# Altium schematic sheet Power.SchDoc
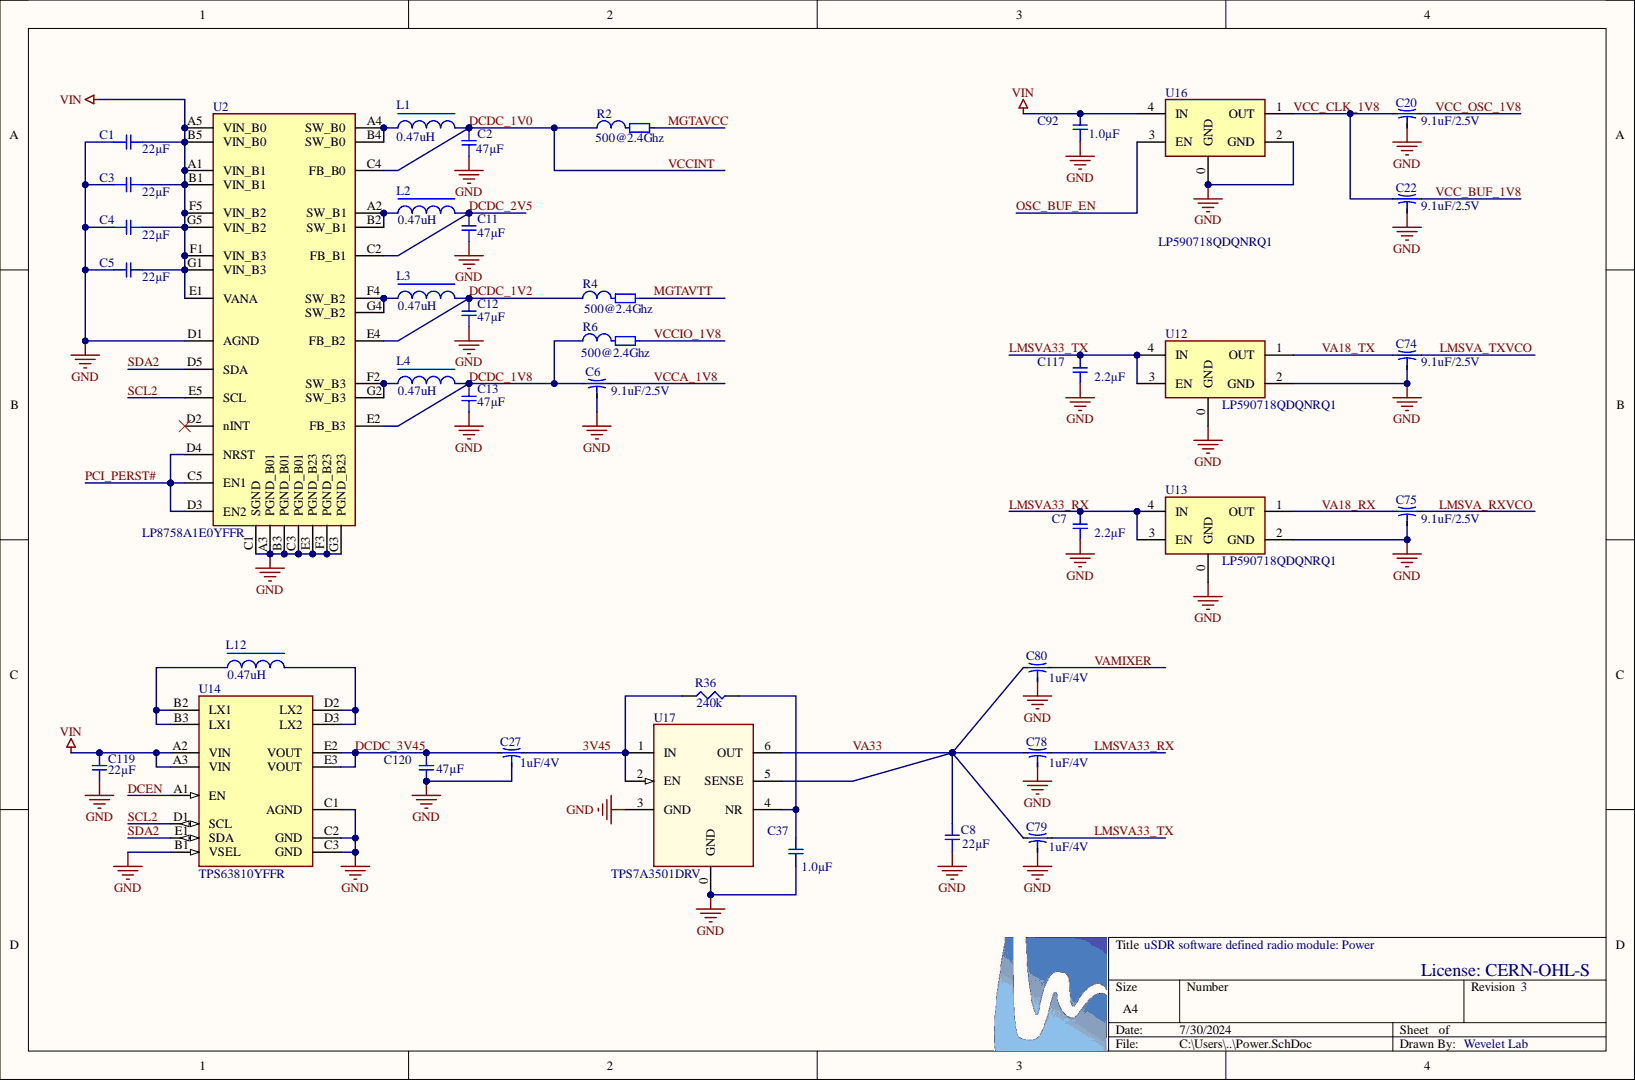
[diagram p0: Power.SchDoc]

## Parts
C1  value 22µF  [SMD-0603-CAP_HighDensity]  (hidden comment: GRM188C81A226ME01D)
C2  value 47µF  [SMD-0603-CAP_HighDensity]  (hidden comment: GRM188C80G476ME01D)
C3  value 22µF  [SMD-0603-CAP_HighDensity]  (hidden comment: GRM188C81A226ME01D)
C4  value 22µF  [SMD-0603-CAP_HighDensity]  (hidden comment: GRM188C81A226ME01D)
C5  value 22µF  [SMD-0603-CAP_HighDensity]  (hidden comment: GRM188C81A226ME01D)
C6  value 9.1uF/2.5V  [NFM15]  (hidden comment: NFM15PC915D0E3)
C7  value 2.2µF  [SMD-0201C]  (hidden comment: GRM033C80G225ME01D)
C8  value 22µF  [SMD-0603-CAP_HighDensity]  (hidden comment: GRM188C81A226ME01D)
C11  value 47µF  [SMD-0603-CAP_HighDensity]  (hidden comment: GRM188C80G476ME01D)
C12  value 47µF  [SMD-0603-CAP_HighDensity]  (hidden comment: GRM188C80G476ME01D)
C13  value 47µF  [SMD-0603-CAP_HighDensity]  (hidden comment: GRM188C80G476ME01D)
C20  value 9.1uF/2.5V  [NFM15]  (hidden comment: NFM15PC915D0E3)
C22  value 9.1uF/2.5V  [NFM15]  (hidden comment: NFM15PC915D0E3)
C27  value 1uF/4V  [NFM15]  (hidden comment: NFM15PC105D0G3)
C37  value 1.0µF  [SMD-0201C]  (hidden comment: GRM033D70J105ME01D)
C74  value 9.1uF/2.5V  [NFM15]  (hidden comment: NFM15PC915D0E3)
C75  value 9.1uF/2.5V  [NFM15]  (hidden comment: NFM15PC915D0E3)
C78  value 1uF/4V  [NFM15]  (hidden comment: NFM15PC105D0G3)
C79  value 1uF/4V  [NFM15]  (hidden comment: NFM15PC105D0G3)
C80  value 1uF/4V  [NFM15]  (hidden comment: NFM15PC105D0G3)
C92  value 1.0µF  [SMD-0201C]  (hidden comment: GRM033D70J105ME01D)
C117  value 2.2µF  [SMD-0201C]  (hidden comment: GRM033C80G225ME01D)
C119  value 22µF  [SMD-0603-CAP_HighDensity]  (hidden comment: GRM188C81A226ME01D)
C120  value 47µF  [SMD-0603-CAP_HighDensity]  (hidden comment: GRM188C80G476ME01D)
L1  value 0.47uH  [LSCNx1608]  (hidden comment: LSCNB1608HKTR47MD)
L2  value 0.47uH  [LSCNx1608]  (hidden comment: LSCNB1608HKTR47MD)
L3  value 0.47uH  [LSCNx1608]  (hidden comment: LSCNB1608HKTR47MD)
L4  value 0.47uH  [LSCNx1608]  (hidden comment: LSCNB1608HKTR47MD)
L12  value 0.47uH  [LSCNx1608]  (hidden comment: LSCNB1608HKTR47MD)
R2  value 500@2.4Ghz  [SMD-0201-RES]  (hidden comment: BLF03RD501GNE)
R4  value 500@2.4Ghz  [SMD-0201-RES]  (hidden comment: BLF03RD501GNE)
R6  value 500@2.4Ghz  [SMD-0201-RES]  (hidden comment: BLF03RD501GNE)
R36  240k  [SMD-0201-RES]
U2  LP8758A1E0YFFR  [BGA WLCSP35]
U12  LP590718QDQNRQ1  [X2SON(1x1)]
U13  LP590718QDQNRQ1  [X2SON(1x1)]
U14  TPS63810YFFR  [BGA DSBGA-15]
U16  LP590718QDQNRQ1  [X2SON(1x1)]
U17  TPS7A3501DRV  [W-SON-6]

## Nets
GND: C1.1 C2.2 C3.1 C4.1 C5.1 C6.2 C7.2 C8.1 C11.2 C12.2 C13.2 C20.2 C22.2 C27.2 C37.1 C74.2 C75.2 C78.2 C79.2 C80.2 C92.1 C117.2 C119.2 C120.2 U2.A3(PGND_B01) U2.B3(PGND_B01) U2.C1(SGND) U2.C3(PGND_B01) U2.D1(AGND) U2.E3(PGND_B23) U2.F3(PGND_B23) U2.G3(PGND_B23) U12.0(GND) U12.2(GND) U13.0(GND) U13.2(GND) U14.B1(VSEL) U14.C1(AGND) U14.C2(GND) U14.C3(GND) U16.0(GND) U16.2(GND) U17.0(GND) U17.3(GND)
VIN: C1.2 C3.2 C4.2 C5.2 C92.2 C119.1 U2.A1(VIN_B1) U2.A5(VIN_B0) U2.B1(VIN_B1) U2.B5(VIN_B0) U2.E1(VANA) U2.F1(VIN_B3) U2.F5(VIN_B2) U2.G1(VIN_B3) U2.G5(VIN_B2) U14.A2(VIN) U14.A3(VIN) U16.4(IN)
3V45: C27.3 R36.1 U17.1(IN) U17.2(EN)
DCDC_1V0: C2.1 L1.2 R2.1 U2.C4(FB_B0)
DCDC_1V2: C12.1 L3.2 R4.1 U2.E4(FB_B2)
DCDC_1V8: C6.1 C13.1 L4.2 R6.1 U2.E2(FB_B3)
DCDC_2V5: C11.1 L2.2 U2.C2(FB_B1)
DCDC_3V45: C27.1 C120.1 U14.E2(VOUT) U14.E3(VOUT)
DCEN: U14.A1(EN)
LMSVA33_RX: C7.1 C78.3 U13.3(EN) U13.4(IN)
LMSVA33_TX: C79.3 C117.1 U12.3(EN) U12.4(IN)
LMSVA_RXVCO: C75.3
LMSVA_TXVCO: C74.3
MGTAVCC: R2.2
MGTAVTT: R4.2
OSC_BUF_EN: U16.3(EN)
PCI_PERST#: U2.C5(EN1) U2.D3(EN2) U2.D4(NRST)
SCL2: U2.E5(SCL) U14.D1(SCL)
SDA2: U2.D5(SDA) U14.E1(SDA)
VA18_RX: C75.1 U13.1(OUT)
VA18_TX: C74.1 U12.1(OUT)
VA33: C8.2 C78.1 C79.1 C80.1 U17.5(SENSE) U17.6(OUT)
VAMIXER: C80.3
VCC_BUF_1V8: C22.3
VCC_CLK_1V8: C20.1 C22.1 U16.1(OUT)
VCC_OSC_1V8: C20.3
VCCA_1V8: C6.3
VCCIO_1V8: R6.2
NetC37_2: C37.2 R36.2 U17.4(NR)
NetL1_1: L1.1 U2.A4(SW_B0) U2.B4(SW_B0)
NetL2_1: L2.1 U2.A2(SW_B1) U2.B2(SW_B1)
NetL3_1: L3.1 U2.F4(SW_B2) U2.G4(SW_B2)
NetL4_1: L4.1 U2.F2(SW_B3) U2.G2(SW_B3)
NetL12_1: L12.1 U14.B2(LX1) U14.B3(LX1)
NetL12_2: L12.2 U14.D2(LX2) U14.D3(LX2)

Not connected: U2 D2(nINT)

## Library data of the parts
C1 C3-C5 C8 C119 = GRM188C81A226ME01D: CAP CER 22UF 10V X6S 0603; Manufacturer: Murata Electronics North America; Tolerance: ±20%; Applications: General Purpose; Component Kind: Standard; Datasheets: https://www.murata.com/-/media/webrenewal/support/library/catalog/products/capacitor/ceramiccapacitor/c02e.ashx?la=en-us&cvid=20210120024548000000; Failure Rate: Surface Mount, MLCC; Mounting Type: 0603 (1608 Metric); Operating Temperature: -55°C ~ 105°C; Package / Case: 0.063" L x 0.031" W (1.60mm x 0.80mm); Part Number: GRM188C81A226ME01D; Part Status: Active; Series: GRM; Temperature Coefficient: X6S; Voltage: 10V; Library Name: USDR.IntLib
C2 C11-C13 C120 = GRM188C80G476ME01D: CAP CER 47UF 4V X6S 0603; Manufacturer: Murata Electronics North America; Tolerance: ±20%; Applications: General Purpose; Component Kind: Standard; Datasheets: https://www.murata.com/-/media/webrenewal/support/library/catalog/products/capacitor/ceramiccapacitor/c02e.ashx?la=en-us&cvid=20210120024548000000; Digi-Key Part Number: 490-13246-2-ND; Failure Rate: Surface Mount, MLCC; Mounting Type: 0603 (1608 Metric); Operating Temperature: -55°C ~ 105°C; Package / Case: 0.063" L x 0.031" W (1.60mm x 0.80mm); Part Number: GRM188C80G476ME01D; Part Status: Active; Series: GRM; Temperature Coefficient: X6S; Voltage: 4V; Library Name: USDR.IntLib
C6 C20 C22 C74 C75 = NFM15PC915D0E3: Filter EMI 0402 9.1uF/2.5V; Manufacturer: MuRata; Tolerance: 20%; U/P/TKE: 2.5V/2A/30mO/-55+105℃; Component Kind: Standard; Link: https://octopart.com/nfm15pc146r0g3d-murata-94414995; Name: NFM15PC915D0E3; PDF: https://datasheet.octopart.com/NFM15PC146R0G3D-Murata-datasheet-138760002.pdf; Library Name: USDR.IntLib
C7 C117 = GRM033C80G225ME01D: CAP CER 2.2UF 4V X6S 0201; Manufacturer: Murata Electronics North America; @ qty: 0; Minimum Quantity: 1; Tolerance: ±20%; Unit Price (USD): 0.54; Voltage: 6.3V; Applications: General Purpose; Component Kind: Standard; Datasheets: http://psearch.en.murata.com/capacitor/product/GRM035R60J475ME15%23.pdf; Digi-Key Part Number: 490-GRM033C80G225ME01DTR-ND; Factory Stock: 0; Failure Rate: Surface Mount, MLCC; Features: Derating Recommended; Height - Seated (Max): 0.022" (0.55mm); Image: //media.digikey.com/Renders/Murata%20Renders/ZRB-SERIES-0402.jpg; Lead Spacing: -; Mounting Type: 0201 (0603 Metric); Operating Temperature: -55°C ~105°C; Package / Case: 0.024" L x 0.012" W (0.60mm x 0.30mm); Packaging: Cut Tape (CT); Part Number: GRM033C80G225ME01D; Part Status: Active; Quantity Available: 67188; Ratings: -; Series: GRM; Size / Dimension: -; Temperature Coefficient: X6S; Thickness (Max): -; Library Name: USDR.IntLib
C27 C78-C80 = NFM15PC105D0G3: Filter EMI 0402 1uF/4V; Manufacturer: MuRata; Tolerance: 20%; U/P/TKE: 4V/2A/30mO/-55+105℃; Component Kind: Standard; Link: https://octopart.com/nfm15pc146r0g3d-murata-94414995; Name: NFM15PC105D0G3; PDF: https://datasheet.octopart.com/NFM15PC146R0G3D-Murata-datasheet-138760002.pdf; Library Name: USDR.IntLib
C37 C92 = GRM033D70J105ME01D: CAP CER 1.0UF 6.3V X7T 0201; Manufacturer: Murata Electronics North America; @ qty: 0; Minimum Quantity: 1; Tolerance: ±20%; Unit Price (USD): 0.54; Applications: General Purpose; Component Kind: Standard; Datasheets: http://psearch.en.murata.com/capacitor/product/GRM035R60J475ME15%23.pdf; Digi-Key Part Number: 490-GRM033D70J105ME01DTR-ND; Factory Stock: 0; Failure Rate: Surface Mount, MLCC; Features: Derating Recommended; Height - Seated (Max): 0.022" (0.55mm); Image: //media.digikey.com/Renders/Murata%20Renders/ZRB-SERIES-0402.jpg; Lead Spacing: -; Mounting Type: 0201 (0603 Metric); Operating Temperature: -55°C ~125°C; Package / Case: 0.024" L x 0.012" W (0.60mm x 0.30mm); Packaging: Cut Tape (CT); Part Number: GRM033D70J105ME01D; Part Status: Active; Quantity Available: 67188; Ratings: -; Series: GRM; Size / Dimension: -; Temperature Coefficient: X7T; Thickness (Max): -; Voltage: 6.3V; Library Name: USDR.IntLib
L1-L4 L12 = LSCNB1608HKTR47MD: COIL 0.47uH/3.3A 43mOhm; Manufacturer: Taiyo Yuden; Previous Part Number: MCHK1608TR47MKN; Tolerance: ±20%; Component Kind: Standard; Link: https://ds.yuden.co.jp/TYCOMPAS/ut/specSheet?pn=LSCNB1608HKTR47MD; Name: LSCNB1608HKTR47MD; Library Name: USDR.IntLib
R2 R4 R6 = BLF03RD501GNE: BEAD 0.36Ohm/500mA 500@2.4Ghz; Manufacturer: Murata Electronics North America; Tolerance: ±40%; Component Kind: Standard; Link: https://product.tdk.com/system/files/dam/doc/product/inductor/inductor/smd/catalog/inductor_commercial_high-frequency_mlg0603p_en.pdf; Name: BLF03RD501GNE; Library Name: USDR.IntLib
R36 = ERJ-1GEJ244C: RES SMD 240K OHM 5% 1/20W 0201; Manufacturer: Panasonic Electronic Components; @ qty: 1500; Factory Stock: 0; Minimum Quantity: 1; Power (Watts): 0.05W, 1/20W; Temperature Coefficient: ±200ppm/°C; Unit Price (USD): 0.00787; Component Kind: Standard; Composition: Thick Film; Datasheets: //media.digikey.com/pdf/Data%20Sheets/Panasonic%20Resistors%20Thermistors%20PDFs/ERJ%205%25.pdf; Digi-Key Part Number: P240KAGCT-ND; Failure Rate: -; Features: -; Height - Seated (Max): 0.010" (0.26mm); Image: //media.digikey.com/Renders/Panasonic%20Renders/ERJ-0201%20Pkg.jpg; Number of Terminations: 2; Operating Temperature: -55°C ~ 125°C; Package / Case: 0201 (0603 Metric); Packaging: Cut Tape (CT); Part Number: ERJ-1GEJ244C; Part Status: Active; Quantity Available: 51508; Series: ERJ; Size / Dimension: 0.024" L x 0.012" W (0.60mm x 0.30mm); Supplier Device Package: 0201; Tolerance: ±5%; Value: 240k; Library Name: USDR.IntLib
U2 = LP8758A1E0YFFR: IC 4xDC/DC; Manufacturer: TI; Misc: 4x  4A DC/DCs, i2c control; Swap: No; U/P/TKE: 2.5-5.5V to 0.5-3.3V; Component Kind: Standard; Link: https://octopart.com/lp8758a1e0yffr-texas+instruments-68058967; Name: LP8758A1E0YFFR; Library Name: USDR.IntLib
U12 U13 U16 = LP590718QDQNRQ1: LDO 1.8V 250ma; Manufacturer: TI; Misc: 1.8V 200ma LDO; PDF: d:\Proj\Components\Разное\FNETHE025.pdf; Swap: No; Component Kind: Standard; Name: LP590718QDQNRQ1; Library Name: USDR.IntLib
U14 = TPS63810YFFR: IC, VolReg, I2C, DSBGA-15; Manufacturer: TI; Component Kind: Standard; Name: TPS63810YFFR; PDF: https://www.ti.com/lit/ds/symlink/tps63810.pdf?ts=1642151125653; Library Name: USDR.IntLib
U17 = TPS7A3501: IC; Manufacturer: TI; Misc: step down switching regulator; Swap: No; Component Kind: Standard; ComponentLink1Description: Link; ComponentLink1URL: https://octopart.com/search?q=TPS7A3501DRV&currency=USD&specs=0&in_stock_only=1; Name: TPS7A3501DRV; Library Name: USDR.IntLib
